# Altium schematic sheet connectors.SchDoc(connectors)
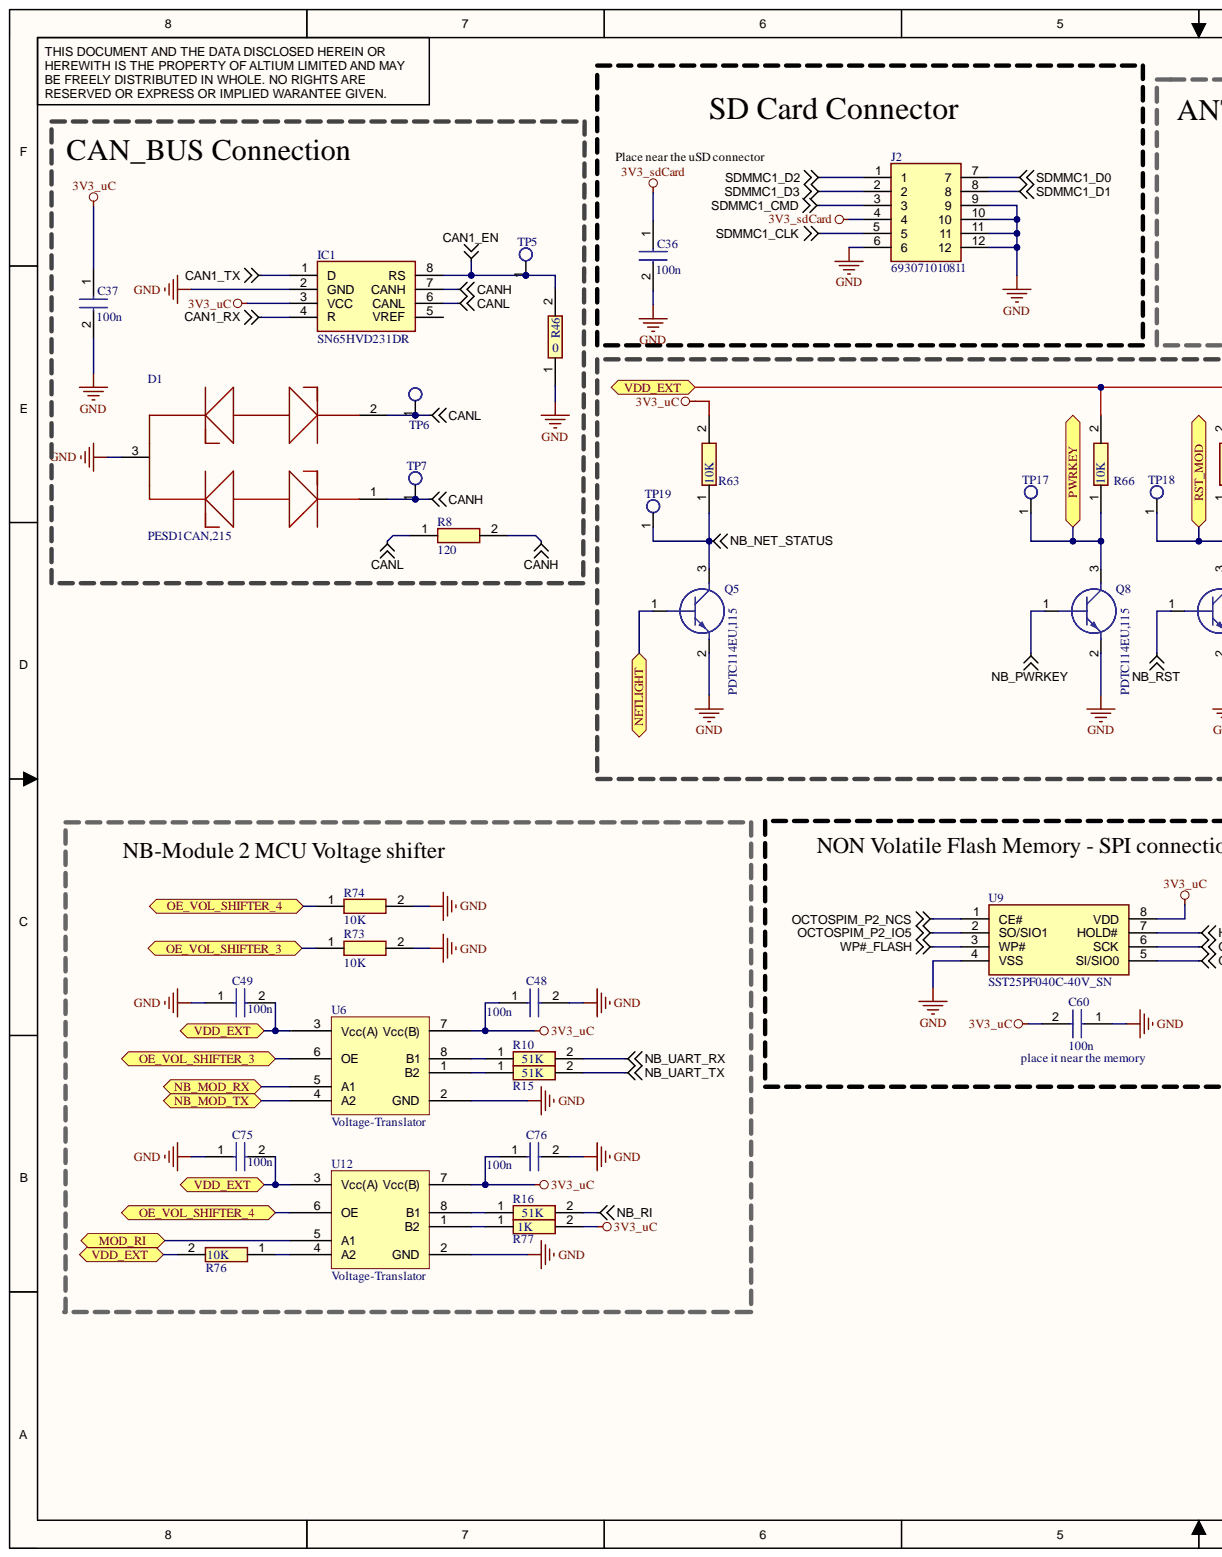
[diagram p0: connectors.SchDoc, left]
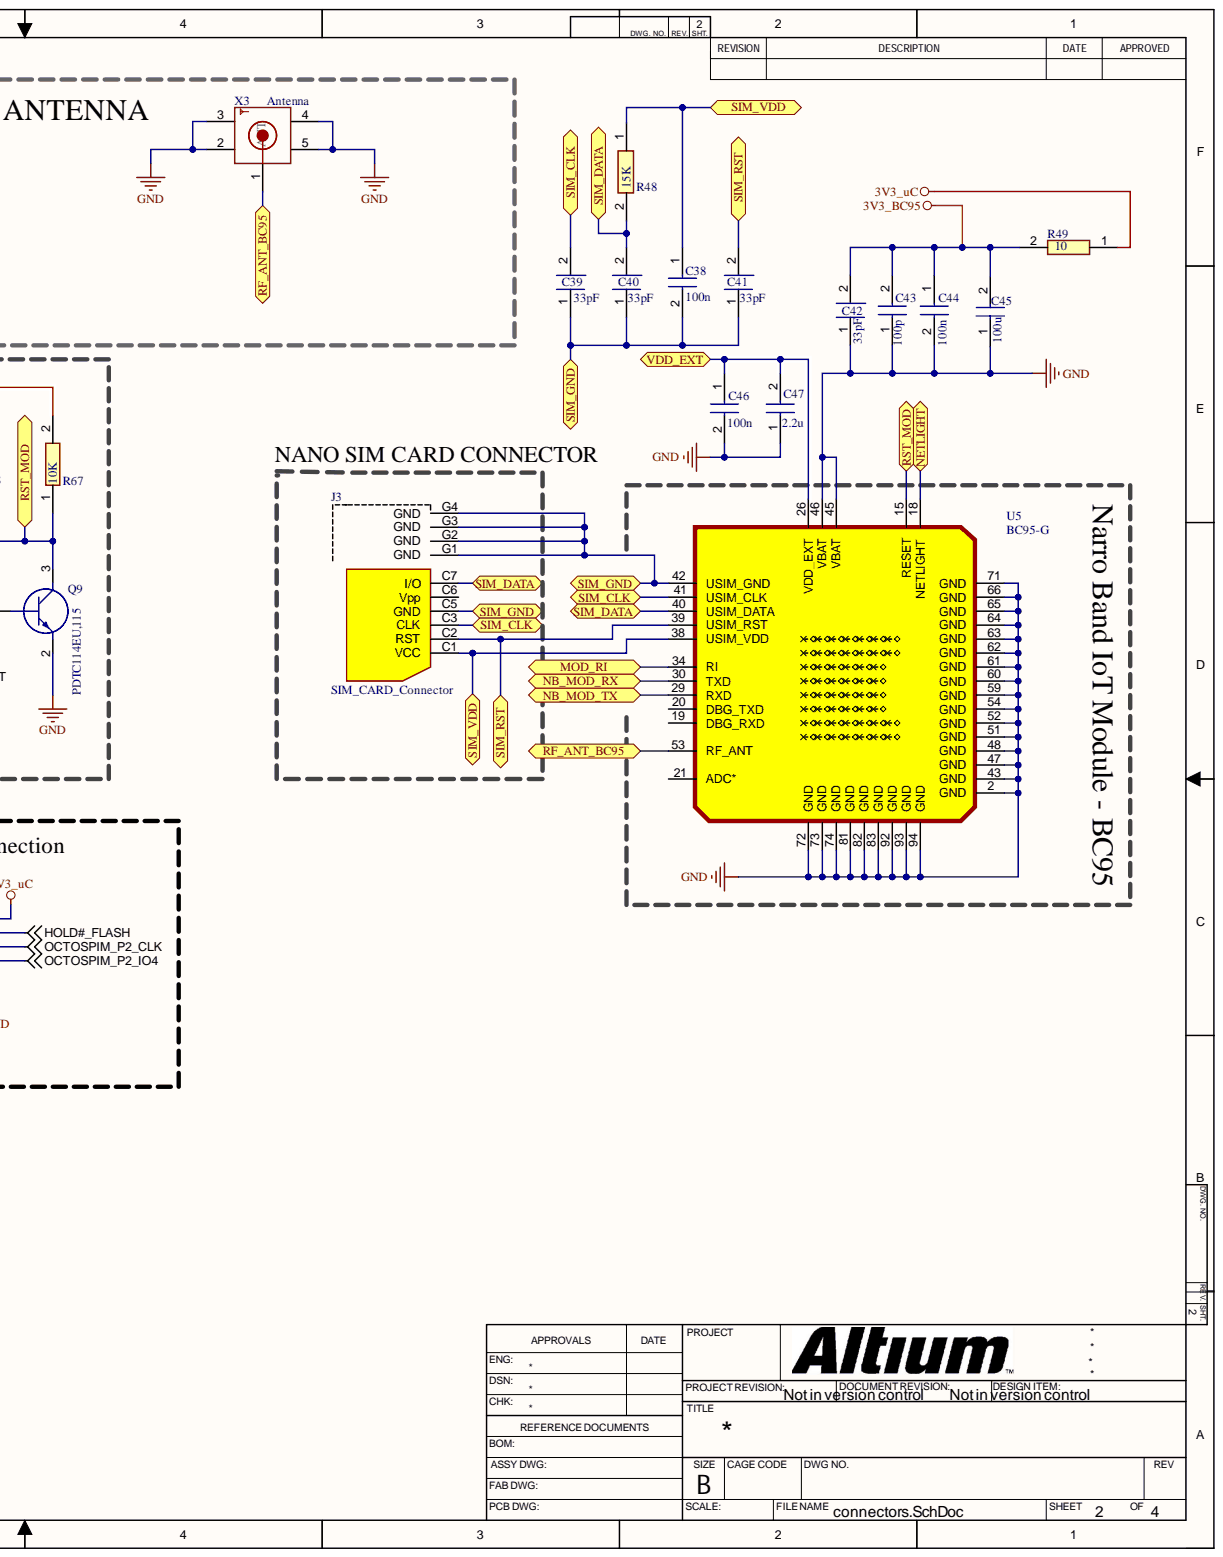
[diagram p1: connectors.SchDoc, right]

## Parts
C36  100n  [CAPC1608X87N]
C37  100n  [CAPC1608X87N]
C38  100n  [CAPC1608X87N]
C39  33pF  [CAPC1608X87N]
C40  33pF  [CAPC1608X87N]
C41  33pF  [CAPC1608X87N]
C42  33pF  [CAPC1608X87N]
C43  100p  [CAPC1608X87N]
C44  100n  [CAPC1608X87N]
C45  100u  [CAPC3216X180N]
C46  100n  [CAPC1608X87N]
C47  2.2u  [CAPC1608X50N]
C48  100n  [CAPC1608X87N]
C49  100n  [CAPC1608X87N]
C60  100n  [CAPC1608X87N]
C75  100n  [CAPC1608X87N]
C76  100n  [CAPC1608X87N]
D1  PESD1CAN,215  [SOT95P230X110-3N]
IC1  SN65HVD231DR  [SOIC127P600X175-8N]
J2  693071010811  [693071010811]
J3  SIM_CARD_Connector  [SIM8060601400A]
Q5  PDTC114EU,115  [SOT65P210X110-3N]
Q8  PDTC114EU,115  [SOT65P210X110-3N]
Q9  PDTC114EU,115  [SOT65P210X110-3N]
R8  120  [ERA2V_(0402)]
R10  51K  [RESC6432X120N]
R15  51K  [RESC6432X120N]
R16  51K  [RESC6432X120N]
R46  0  [RESC1608X55N]
R48  15K  [RESC1508X50N]
R49  10  [RESCAF_LVK12-4]
R63  10K  [ERJ3EK_(0603)]
R66  10K  [ERJ3EK_(0603)]
R67  10K  [ERJ3EK_(0603)]
R73  10K  [ERJ3EK_(0603)]
R74  10K  [ERJ3EK_(0603)]
R76  10K  [ERJ3EK_(0603)]
R77  1K  [RESC1608X55N]
TP5  test_point  [test_point]  (value not drawn)  (no BOM)
TP6  test_point  [test_point]  (value not drawn)  (no BOM)
TP7  test_point  [test_point]  (value not drawn)  (no BOM)
TP17  test_point  [test_point]  (value not drawn)  (no BOM)
TP18  test_point  [test_point]  (value not drawn)  (no BOM)
TP19  test_point  [test_point]  (value not drawn)  (no BOM)
U5  BC95-G  [XCVR_BC95GJB02STD]
U6  Voltage-Translator  [SOP65P490X110-8N]
U9  SST25PF040C-40V_SN  [SOIC127P600X175-8N]
U12  Voltage-Translator  [SOP65P490X110-8N]
X3  Antenna  [PCBSMAFRAHT]

## Ports
MOD_RI, NB_MOD_RX, NB_MOD_TX, NETLIGHT, OE_VOL_SHIFTER_3, OE_VOL_SHIFTER_4, PWRKEY, RF_ANT_BC95, RST_MOD, SIM_CLK, SIM_DATA, SIM_GND, SIM_RST, SIM_VDD, VDD_EXT

## Nets
3V3_BC95: C42.2 C43.2 C44.1 C45.2 R49.2
3V3_sdCard: C36.1 J2.4
3V3_uC: C37.1 C48.1 C60.2 C76.1 IC1.3(VCC) R49.1 R63.2 R77.2 U6.7(Vcc(B)) U9.8(VDD) U12.7(Vcc(B))
CAN1_EN: IC1.8(RS) R46.2 TP5.1
CAN1_RX: IC1.4(R)
CAN1_TX: IC1.1(D)
CANH: D1.1 IC1.7(CANH) R8.2 TP7.1
CANL: D1.2 IC1.6(CANL) R8.1 TP6.1
GND: C36.2 C37.2 C42.1 C43.1 C44.2 C45.1 C46.2 C47.1 C48.2 C49.1 C60.1 C75.1 C76.2 D1.3 IC1.2(GND) J2.6 J2.9 J2.10 J2.11 J2.12 Q5.2 Q8.2 Q9.2 R46.1 R73.2 R74.2 U5.2(GND) U5.43(GND) U5.45(VBAT) U5.46(VBAT) U5.47(GND) U5.48(GND) U5.51(GND) U5.52(GND) U5.54(GND) U5.59(GND) U5.60(GND) U5.61(GND) U5.62(GND) U5.63(GND) U5.64(GND) U5.65(GND) U5.66(GND) U5.71(GND) U5.72(GND) U5.73(GND) U5.74(GND) U5.81(GND) U5.82(GND) U5.83(GND) U5.92(GND) U5.93(GND) U5.94(GND) U6.2(GND) U9.4(VSS) U12.2(GND) X3.2 X3.3 X3.4 X3.5
HOLD#_FLASH: U9.7(HOLD#)
NB_NET_STATUS: Q5.3 R63.1 TP19.1
NB_PWRKEY: Q8.1
NB_RI: R16.2
NB_RST: Q9.1
NB_UART_RX: R10.2
NB_UART_TX: R15.2
OCTOSPIM_P2_CLK: U9.6(SCK)
OCTOSPIM_P2_IO4: U9.5(SI/SIO0)
OCTOSPIM_P2_IO5: U9.2(SO/SIO1)
OCTOSPIM_P2_NCS: U9.1(CE#)
SDMMC1_CLK: J2.5
SDMMC1_CMD: J2.3
SDMMC1_D0: J2.7
SDMMC1_D1: J2.8
SDMMC1_D2: J2.1
SDMMC1_D3: J2.2
WP#_FLASH: U9.3(WP#)
MOD_RI: U5.34(RI) U12.5(A1) ; port MOD_RI
NB_MOD_RX: U5.30(TXD) U6.5(A1) ; port NB_MOD_RX
NB_MOD_TX: U5.29(RXD) U6.4(A2) ; port NB_MOD_TX
NETLIGHT: Q5.1 U5.18(NETLIGHT) ; port NETLIGHT
OE_VOL_SHIFTER_3: R73.1 U6.6(OE) ; port OE_VOL_SHIFTER_3
OE_VOL_SHIFTER_4: R74.1 U12.6(OE) ; port OE_VOL_SHIFTER_4
PWRKEY: Q8.3 R66.1 TP17.1 ; port PWRKEY
RF_ANT_BC95: U5.53(RF_ANT) X3.1(ANT) ; port RF_ANT_BC95
RST_MOD: Q9.3 R67.1 TP18.1 U5.15(RESET) ; port RST_MOD
SIM_CLK: C39.2 J3.C3(CLK) U5.41(USIM_CLK) ; port SIM_CLK
SIM_DATA: C40.2 J3.C7(I/O) R48.2 U5.40(USIM_DATA) ; port SIM_DATA
SIM_GND: C38.2 C39.1 C40.1 C41.1 J3.C5(GND) J3.G1(GND) J3.G2(GND) J3.G3(GND) J3.G4(GND) U5.42(USIM_GND) ; port SIM_GND
SIM_RST: C41.2 J3.C2(RST) U5.39(USIM_RST) ; port SIM_RST
SIM_VDD: C38.1 J3.C1(VCC) R48.1 U5.38(USIM_VDD) ; port SIM_VDD
VDD_EXT: C46.1 C47.2 C49.2 C75.2 R66.2 R67.2 R76.2 U5.26(VDD_EXT) U6.3(Vcc(A)) U12.3(Vcc(A)) ; port VDD_EXT
NetR10_1: R10.1 U6.8(B1)
NetR15_1: R15.1 U6.1(B2)
NetR16_1: R16.1 U12.8(B1)
NetR76_1: R76.1 U12.4(A2)
NetR77_1: R77.1 U12.1(B2)

Not connected: IC1 5(VREF); J3 C6(Vpp); U5 1 3 4 5 6 7 8 9 10 11 12 13 14 16 17 19(DBG_RXD) 20(DBG_TXD) 21(ADC*) 22 23 24 25 27 28 31 32 33 35 36 37 44 49 50 55 56 57 58 67 68 69 70 75 76 77 78 79 80 84 85 86 87 88 89 90 91

## Library data of the parts
C36-C38 C44 C46 C48 C49 C60 C75 C76 = 885012206071: Capacitor; Manufacturer_Name: Wurth Elektronik; Component Kind: Standard; Datasheet Link: https://katalog.we-online.com/pbs/datasheet/885012206071.pdf; Height: 0.87mm; Manufacturer_Part_Number: 885012206071
C39-C42 = VJ0603A330KXXCW1BC: Cap Ceramic 33pF 25V C0G 10% SMD 0603 125°C Paper T/R; Manufacturer: Vishay Vitramon; Dielectric Material: Tantalum; Capacitance: 33pF; Case Code (Imperial): 0603; Case Code (Metric): 1608; Case/Package: 0603; Category: Ceramic Capacitors; Component Kind: Standard; ComponentLink1Description: http://www.mouser.com/ds/2/427/vjw1bcbascomseries-223529.pdf?src-supplier=Mouser; ComponentLink1URL: http://www.mouser.com/ds/2/427/vjw1bcbascomseries-223529.pdf?src-supplier=Mouser; ComponentLink2Description: http://www.vishay.com/docs/28548/vjw1bcbascomseries.pdf?src-supplier=Avnet; ComponentLink2URL: http://www.vishay.com/docs/28548/vjw1bcbascomseries.pdf?src-supplier=Avnet; ComponentLink3Description: https://www.vishay.com/docs/28548/vjw1bcbascomseries.pdf?src-supplier=Digi-Key; ComponentLink3URL: https://www.vishay.com/docs/28548/vjw1bcbascomseries.pdf?src-supplier=Digi-Key; Construction: Flat; Depth: 0.8mm; Dielectric: C0G; Height: 0.95mm; Lead Free: Lead Free; Length: 1.6mm; Manufacturer Part Number: VJ0603A330KXXCW1BC; Max Operating Temperature: 125°C; Min Operating Temperature: -55°C; Mount: Surface Mount; Package Quantity: 4000; Packaging: Tape and Reel; RoHS: Yes; RoHS Compliant: Yes; Series: VJ W1BC; Stock 1: 1121; Supplier 1: Mouser; Supplier Part Number 1: 77-VJ0603A330KXXCBC; Termination: SMD/SMT; Tolerance: 10%; Voltage Rating (DC): 25V; Width: 0.8mm; Library Name: shm_schem_lib.SchLib
C43 = 885012206003: Capacitor; Manufacturer_Name: Wurth Elektronik; Component Kind: Standard; Datasheet Link: https://katalog.we-online.com/pbs/datasheet/885012206003.pdf; Height: 0.87mm; Manufacturer_Part_Number: 885012206003
C45 = GRM31CR60J107ME39L: Capacitor; Manufacturer_Name: Murata Electronics; Component Kind: Standard; Datasheet Link: https://componentsearchengine.com//GRM31CR60J107ME39L.pdf; Height: 1.8mm; Manufacturer_Part_Number: GRM31CR60J107ME39L; Mouser2 Part Number: 81-GRM31CR60J107ME9L; Mouser2 Price/Stock: https://www.mouser.com/Search/Refine.aspx?Keyword=81-GRM31CR60J107ME9L
C47 = EMK107BJ225KA-T: Capacitor; Manufacturer_Name: TAIYO YUDEN; Component Kind: Standard; Height: 0.5mm; Manufacturer_Part_Number: EMK107BJ225KA-T
D1 = PESD1CAN,215: ESD Suppressor Diode TVS Bi-Dir 24V Automotive 3-Pin SOT-23 T/R / TVS DIODE 24VWM 70VC SOT23; Manufacturer: Nexperia; Category: ESD and Circuit Protection ICs; Component Kind: Standard; ComponentLink1Description: http://www.farnell.com/datasheets/109497.pdf?src-supplier=Farnell; ComponentLink1URL: http://www.farnell.com/datasheets/109497.pdf?src-supplier=Farnell; ComponentLink2Description: https://assets.nexperia.com/documents/data-sheet/PESD1CAN.pdf?src-supplier=Digi-Key; ComponentLink2URL: https://assets.nexperia.com/documents/data-sheet/PESD1CAN.pdf?src-supplier=Digi-Key; ComponentLink3Description: http://www.farnell.com/datasheets/109497.pdf?src-supplier=Newark; ComponentLink3URL: http://www.farnell.com/datasheets/109497.pdf?src-supplier=Newark; Manufacturer Part Number: PESD1CAN,215; RoHS: Yes; Stock 1: 129000; Supplier 1: RSComponents; Supplier Part Number 1: 1242373; Library Name: shm_schem_lib.SchLib
IC1 = SN65HVD231DR: Integrated Circuit; Manufacturer_Name: Texas Instruments; Component Kind: Standard; Datasheet Link: http://www.ti.com/lit/gpn/sn65hvd231; Height: 1.75mm; Manufacturer_Part_Number: SN65HVD231DR
J2 = 693071010811: Connector; Manufacturer_Name: Wurth Elektronik; Component Kind: Standard; Datasheet Link: https://www.we-online.de/katalog/datasheet/693071010811.pdf; Height: 2.13mm; Manufacturer_Part_Number: 693071010811
J3 = SIM8060-6-0-14-00-A: Sim Nano Hinged, 6P, 1.40MM Prof; Manufacturer: GCT; Component Kind: Standard; Manufacturer Part Number: SIM8060-6-0-14-00-A; Supplier 1: Digi-Key; Supplier Part Number 1: 2073-SIM8060-6-0-14-00-ACT-ND; Library Name: shm_schem_lib.SchLib
Q5 Q8 Q9 = PDTC114EU,115: Transistor BJT NPN; Manufacturer_Name: Nexperia; Component Kind: Standard; Datasheet Link: https://componentsearchengine.com//PDTC114EU,115.pdf; Height: 1.1mm; Manufacturer_Part_Number: PDTC114EU,115; Mouser2 Part Number: 771-PDTC114EU115; Mouser2 Price/Stock: https://www.mouser.com/Search/Refine.aspx?Keyword=771-PDTC114EU115
R8 = ERA-2VEB1200X: Resistor; Manufacturer_Name: Panasonic; Component Kind: Standard; Datasheet Link: http://industrial.panasonic.com/cdbs/www-data/pdf/RDM0000/RDM0000C331.pdf; Height: 0.4mm; Manufacturer_Part_Number: ERA-2VEB1200X
R10 R15 R16 = 352251KFT: Resistor; Manufacturer_Name: TE Connectivity; Component Kind: Standard; Datasheet Link: http://www.te.com/commerce/DocumentDelivery/DDEController?Action=showdoc&DocId=Data+Sheet%7F9-1773463-7%7FB%7Fpdf%7FEnglish%7FENG_DS_9-1773463-7_B.pdf%7F9-2176231-0; Height: 1.2mm; Manufacturer_Part_Number: 352251KFT
R46 = RC0603FR-070RL: Resistor; Manufacturer_Name: YAGEO (PHYCOMP); Component Kind: Standard; Height: 0.55mm; Manufacturer_Part_Number: RC0603FR-070RL
R48 = RCS060315K0FKEA: Res Thick Film 0603 15K Ohm 1% 0.25W(1/4W) ±100ppm/°C Pad SMD Automotive Medical T/R; Manufacturer: Vishay Dale; Max Power Dissipation: 250mW; Resistance: 15kR; Case Code (Imperial): 0603; Case Code (Metric): 1608; Case/Package: 0603; Category: Chip SMD Resistors; Component Kind: Standard; ComponentLink1Description: http://www.vishay.com/docs/20065/rcse3.pdf?src-supplier=Digi-Key; ComponentLink1URL: http://www.vishay.com/docs/20065/rcse3.pdf?src-supplier=Digi-Key; Features: Automotive AEC-Q200; Height: 0.02inch; Length: 0.061inch; Manufacturer Part Number: RCS060315K0FKEA; Max Operating Temperature: 155°C; Min Operating Temperature: -55°C; Number of Terminations: 2; Packaging: Tape and Reel; Resistor Type: Thick Film; RoHS: Yes; Stock 1: 3973; Supplier 1: Digi-Key; Supplier Part Number 1: 541-2796-1-ND; Temperature Coefficient: 100ppm/°C; Tolerance: 1%; Width: 0.033inch; Library Name: shm_schem_lib.SchLib
R49 = LVK12R010DER: Res Metal Alloy 1206 0.01 Ohm 0.5% 0.5W(1/2W) ±50ppm/C Pad SMD T/R; Manufacturer: Ohmite; Max Power Dissipation: 500mW; Resistance: 10mR; Temperature Coefficient: 50ppm/°C; Case Code (Imperial): 1206; Case Code (Metric): 3216; Case/Package: 1206; Category: Chip SMD Resistors; Component Kind: Standard; ComponentLink1Description: https://datasheet.ciiva.com/7937/575641-7937848.pdf?src-supplier=Element14; ComponentLink1URL: https://datasheet.ciiva.com/7937/575641-7937848.pdf?src-supplier=Element14; ComponentLink2Description: https://datasheet.ciiva.com/7937/575641-7937847.pdf?src-supplier=Element14; ComponentLink2URL: https://datasheet.ciiva.com/7937/575641-7937847.pdf?src-supplier=Element14; ComponentLink3Description: https://datasheet.ciiva.com/7938/lvk-7938167.pdf?src-supplier=Element14; ComponentLink3URL: https://datasheet.ciiva.com/7938/lvk-7938167.pdf?src-supplier=Element14; ComponentLink4Description: https://datasheet.ciiva.com/5469/lvk-5469173.pdf?src-supplier=Mouser; ComponentLink4URL: https://datasheet.ciiva.com/5469/lvk-5469173.pdf?src-supplier=Mouser; ComponentLink5Description: https://datasheet.ciiva.com/16756/70024650-16756989.pdf?src-supplier=Allied+Electronics; ComponentLink5URL: https://datasheet.ciiva.com/16756/70024650-16756989.pdf?src-supplier=Allied+Electronics; ComponentLink6Description: https://datasheet.ciiva.com/15865/40lvk-15865303.pdf?src-supplier=Onlinecomponents.com; ComponentLink6URL: https://datasheet.ciiva.com/15865/40lvk-15865303.pdf?src-supplier=Onlinecomponents.com; ComponentLink7Description: https://datasheet.ciiva.com/2482/res-lvk-2482375.pdf?src-supplier=Digi-Key; ComponentLink7URL: https://datasheet.ciiva.com/2482/res-lvk-2482375.pdf?src-supplier=Digi-Key; ComponentLink8Description: https://datasheet.ciiva.com/2482/res-lvk-2482376.pdf?src-supplier=Digi-Key; ComponentLink8URL: https://datasheet.ciiva.com/2482/res-lvk-2482376.pdf?src-supplier=Digi-Key; ComponentLink9Description: https://datasheet.ciiva.com/2482/res-lvk-2482374.pdf?src-supplier=Digi-Key; ComponentLink9URL: https://datasheet.ciiva.com/2482/res-lvk-2482374.pdf?src-supplier=Digi-Key; Depth: 1.6mm; Features: Moisture Resistant; Height: 0.5mm; Length: 3.2mm; Manufacturer Part Number: LVK12R010DER; Max Operating Temperature: 125°C; Min Operating Temperature: -40°C; Mount: Surface Mount; Number of Terminations: 4; Package Quantity: 1; Packaging: Tape and Reel; Power Rating: 500mW; REACH SVHC: Unknown; Resistor Type: Thick Film,Metal Film,Thin Film; RoHS: Yes; RoHS Compliant: Yes; Stock 1: 154906; Supplier 1: Digi-Key; Supplier Part Number 1: LVK12R010DERCT-ND; Termination: SMD/SMT; Tolerance: 0.5%; Weight: 0.000571oz; Width: 1.6mm; Library Name: shm_schem_lib.SchLib
R63 R66 R67 R73 R74 R76 = ERJ-3EKF1002V: Resistor; Manufacturer_Name: Panasonic; Component Kind: Standard; Datasheet Link: https://componentsearchengine.com//ERJ-3EKF1002V.pdf; Height: 0.55mm; Manufacturer_Part_Number: ERJ-3EKF1002V; Mouser2 Part Number: 667-ERJ-3EKF1002V; Mouser2 Price/Stock: https://www.mouser.com/Search/Refine.aspx?Keyword=667-ERJ-3EKF1002V
R77 = CRCW06031K00FKEAC: Resistor; Manufacturer_Name: Vishay; Component Kind: Standard; Datasheet Link: https://componentsearchengine.com//CRCW06031K00FKEAC.pdf; Height: 0.55mm; Manufacturer_Part_Number: CRCW06031K00FKEAC; Mouser2 Part Number: 71-CRCW06031K00FKEAC; Mouser2 Price/Stock: https://www.mouser.com/Search/Refine.aspx?Keyword=71-CRCW06031K00FKEAC
U5 = MIKROE-3294: NB IoT Click BC95 LTE CAT NB1 Module Add-On Board; Manufacturer: mikroElektronika; Component Kind: Standard; Manufacturer Part Number: MIKROE-3294; RoHS: No; Stock 1: 1; Supplier 1: RSComponents; Supplier Part Number 1: 1840145; Library Name: shm_schem_lib.SchLib
U6 U12 = NTB0102DP,125: Voltage Level Translator 2-CH Bidirectional 8-Pin TSSOP T/R; Manufacturer: NXP Semiconductors; Data Rate: 100Mbps; High Level Output Current: -20uA; Low Level Output Current: 20uA; Max Power Dissipation: 250mW; Max Supply Voltage: 5.5V; Min Supply Voltage: 1.65V; Nominal Supply Current: 3.5uA; Number of Bits: 2; Number of Circuits: 1; Output Type: 3-STATE; Propagation Delay: 5.9ns; Quiescent Current: 40uA; Turn-On Delay Time: 4.4ns; Case/Package: TSSOP; Category: power; Channel Type: Bidirectional; Component Kind: Standard; ComponentLink1Description: https://datasheet.ciiva.com/6614/ntb0102-6614983.pdf?src-supplier=Digi-Key; ComponentLink1URL: https://datasheet.ciiva.com/6614/ntb0102-6614983.pdf?src-supplier=Digi-Key; Logic Function: Translator; Manufacturer Part Number: NTB0102DP,125; Max Operating Temperature: 125°C; Min Operating Temperature: -40°C; Mount: Surface Mount; Number of Channels: 2; Number of Pins: 8; Package Quantity: 3000; Packaging: Tape and Reel; RoHS: Yes; RoHS Compliant: Yes; Series: -; Supplier 1: Digi-Key; Supplier Part Number 1: 568-12310-6-ND; Supply Current: 3.5uA; Library Name: shm_schem_lib.SchLib
U9 = SST25PF040C-40V_SN: Integrated Circuit; Manufacturer_Name: Microchip; Manufacturer_Part_Number: SST25PF040C-40V/SN; Component Kind: Standard; Datasheet Link: https://componentsearchengine.com/Datasheets/1/SST25PF040C-40V_SN.pdf; Height: 1.75mm
X3 = PCB.SMAFRA.HT: CONNSMAJACKR/A50OHMPCB; Manufacturer: Taoglas; Body Material: Brass; Body Plating: Gold; Connector Type: SMA,Socket; Dielectric Material: PTFE; Frequency: 6GHz; Impedance: 50R; Voltage Rating: 500V; Case/Package: SMA; Category: RF / Coaxial Connectors; Component Kind: Standard; ComponentLink1Description: http://taoglas.com/images/product_images/original_images/PCB.SMAFRA.HT.pdf?src-supplier=Avnet; ComponentLink1URL: http://taoglas.com/images/product_images/original_images/PCB.SMAFRA.HT.pdf?src-supplier=Avnet; ComponentLink2Description: http://www.taoglas.com/wp-content/uploads/2016/01/PCB.SMAFRA.HT_.pdf?src-supplier=Digi-Key; ComponentLink2URL: http://www.taoglas.com/wp-content/uploads/2016/01/PCB.SMAFRA.HT_.pdf?src-supplier=Digi-Key; Contact Material: Brass; Contact Plating: Gold; Fastening Type: Threaded; Housing Color: Gold; Manufacturer Part Number: PCB.SMAFRA.HT; Max Frequency: 6GHz; Mount: Through Hole,Surface Mount; Orientation: Right Angle; Package Quantity: 500; Packaging: Tray; RoHS: Yes; Stock 1: 9426; Supplier 1: Digi-Key; Supplier Part Number 1: 931-1361-ND; Termination: Solder; Library Name: shm_schem_lib.SchLib
TP5-TP7 TP17-TP19 = test_point: Library Name: shm_schem_lib.SchLib
